# Altium schematic sheet [10] Port Parallèle.SchDoc("[10] Port Parallèle")
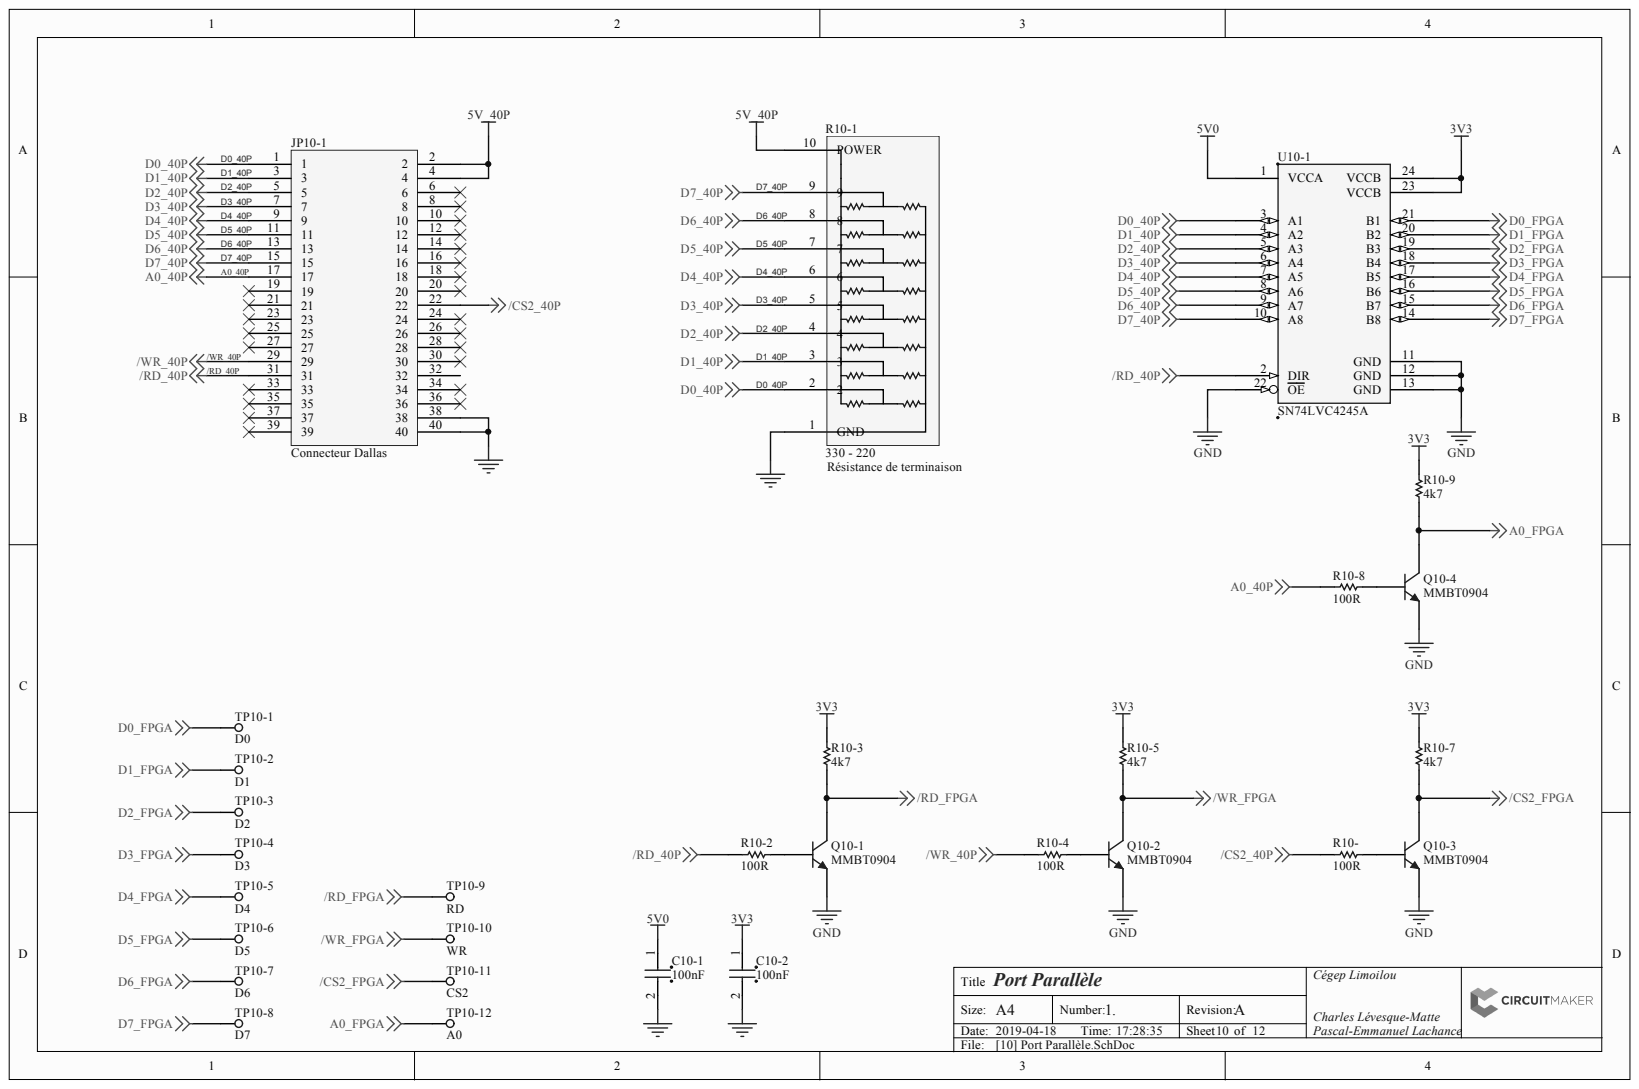
[diagram p0: [10] Port Parallèle.SchDoc]

## Parts
C10-1  100nF  [PCB-mhhqftc5ilnrg4qi8ds6-1]
C10-2  100nF  [PCB-mhhqftc5ilnrg4qi8ds6-1]
JP10-1  Connecteur Dallas  [PCB-2tivjpm26d3sbs9ypqzf-1]
Q10-1  MMBT0904  [PCB-srjv7gtagyvmjlovjbdg-1]
Q10-2  MMBT0904  [PCB-srjv7gtagyvmjlovjbdg-1]
Q10-3  MMBT0904  [PCB-srjv7gtagyvmjlovjbdg-1]
Q10-4  MMBT0904  [PCB-srjv7gtagyvmjlovjbdg-1]
R10-  100R  [PCB-jwfwj9ae82aydnc3cobw-1]
R10-1  330 - 220  [PCBComponent_1]
R10-2  100R  [PCB-jwfwj9ae82aydnc3cobw-1]
R10-3  4k7  [PCB-g8ucq7vw1s2sdhfr4zem-1]
R10-4  100R  [PCB-jwfwj9ae82aydnc3cobw-1]
R10-5  4k7  [PCB-g8ucq7vw1s2sdhfr4zem-1]
R10-7  4k7  [PCB-g8ucq7vw1s2sdhfr4zem-1]
R10-8  100R  [PCB-jwfwj9ae82aydnc3cobw-1]
R10-9  4k7  [PCB-g8ucq7vw1s2sdhfr4zem-1]
TP10-1  D0  [PCB-a7rpxwhzqdkz91v608la-1]
TP10-2  D1  [PCB-a7rpxwhzqdkz91v608la-1]
TP10-3  D2  [PCB-a7rpxwhzqdkz91v608la-1]
TP10-4  D3  [PCB-a7rpxwhzqdkz91v608la-1]
TP10-5  D4  [PCB-a7rpxwhzqdkz91v608la-1]
TP10-6  D5  [PCB-a7rpxwhzqdkz91v608la-1]
TP10-7  D6  [PCB-a7rpxwhzqdkz91v608la-1]
TP10-8  D7  [PCB-a7rpxwhzqdkz91v608la-1]
TP10-9  RD  [PCB-a7rpxwhzqdkz91v608la-1]
TP10-10  WR  [PCB-a7rpxwhzqdkz91v608la-1]
TP10-11  CS2  [PCB-a7rpxwhzqdkz91v608la-1]
TP10-12  A0  [PCB-a7rpxwhzqdkz91v608la-1]
U10-1  SN74LVC4245A  [PCB-19361416-1]

## Nets
3V3: C10-2.1 R10-3.2 R10-5.2 R10-7.2 R10-9.2 U10-1.23(VCCB) U10-1.24(VCCB)
5V0: C10-1.1 U10-1.1(VCCA)
5V_40P: JP10-1.2 JP10-1.4 R10-1.10(POWER)
/CS2_40P: JP10-1.22 R10-.1
/CS2_FPGA: Q10-3.3 R10-7.1 TP10-11.1
/RD_FPGA: Q10-1.3 R10-3.1 TP10-9.1
/WR_FPGA: Q10-2.3 R10-5.1 TP10-10.1
A0_FPGA: Q10-4.3 R10-9.1 TP10-12.1
D0_FPGA: TP10-1.1 U10-1.21(B1)
D1_FPGA: TP10-2.1 U10-1.20(B2)
D2_FPGA: TP10-3.1 U10-1.19(B3)
D3_FPGA: TP10-4.1 U10-1.18(B4)
D4_FPGA: TP10-5.1 U10-1.17(B5)
D5_FPGA: TP10-6.1 U10-1.16(B6)
D6_FPGA: TP10-7.1 U10-1.15(B7)
D7_FPGA: TP10-8.1 U10-1.14(B8)
GND: C10-1.2 C10-2.2 JP10-1.38 JP10-1.40 Q10-1.2 Q10-2.2 Q10-3.2 Q10-4.2 R10-1.1(GND) U10-1.11(GND) U10-1.12(GND) U10-1.13(GND) U10-1.22(OE)
/RD_40P: JP10-1.31 R10-2.1 U10-1.2(DIR)
/WR_40P: JP10-1.29 R10-4.1
A0_40P: JP10-1.17 R10-8.1
D0_40P: JP10-1.1 R10-1.2 U10-1.3(A1)
D1_40P: JP10-1.3 R10-1.3 U10-1.4(A2)
D2_40P: JP10-1.5 R10-1.4 U10-1.5(A3)
D3_40P: JP10-1.7 R10-1.5 U10-1.6(A4)
D4_40P: JP10-1.9 R10-1.6 U10-1.7(A5)
D5_40P: JP10-1.11 R10-1.7 U10-1.8(A6)
D6_40P: JP10-1.13 R10-1.8 U10-1.9(A7)
D7_40P: JP10-1.15 R10-1.9 U10-1.10(A8)
NetQ10-1_1: Q10-1.1 R10-2.2
NetQ10-2_1: Q10-2.1 R10-4.2
NetQ10-3_1: Q10-3.1 R10-.2
NetQ10-4_1: Q10-4.1 R10-8.2

Not connected: JP10-1 6 8 10 12 14 16 18 19 20 21 23 24 25 26 27 28 30 32 33 34 35 36 37 39

## Library data of the parts
C10-1 C10-2 = CMP-1af8d38ac64bc53f-4: Manufacturer: Samsung Electro-Mechanics; Manufacturer Part Number: CL10B104KB8NNNC; Vendor Part Number: 1276-1000-1-ND; Capacitance: 0.1µF; Temperature Coefficient: X7R; Tolerance: ±10%; Vendor: Digikey; Voltage - Rated: 50V; Applications: General Purpose; Component Kind: Standard; HelpURL: https://media.digikey.com/pdf/Data%20Sheets/Samsung%20PDFs/CL_Series_MLCC_ds.pdf; Library Name: CommunityVault; Mounting Type: Surface Mount, MLCC; OctopartID: 1af8d38ac64bc53f; Octopart URL: https://octopart.com/cl10b104kb8nnnc-samsung-7928277; Operating Temperature: -55°C ~ 125°C; Package / Case: 0603 (1608 Metric); Size / Dimension: 0.063" L x 0.031" W (1.60mm x 0.80mm); Thickness (Max): 0.035" (0.90mm)
JP10-1 = CMP-2555ec56f870fa92-1: Conn Shrouded Header (4 Sides) HDR 40 POS 2.54mm Solder RA Thru-Hole Box; Manufacturer Part Number: 30340-5002HB; Gender: Male; Lead-Free Status: Lead Free; Component Kind: Standard; Library Name: CommunityVault; OctopartID: 2555ec56f870fa92; RoHS: Compliant
Q10-1-Q10-4 = CMP-22e1eb52d348fd26-2: Transistor: 200mA 200mW NPN General-Purpose Amplifier, SOT-23, 7IN. Reel; Current Rating: 100 mA; Lead-Free Status: Lead Free; Power Dissipation: 350 mW (max); Component Kind: Standard; Library Name: CommunityVault; OctopartID: 22e1eb52d348fd26
R10- R10-2 R10-4 R10-8 = CMP-8a5c42738545559b-1: RMCF Series 0603 0.1 W 100 Ohm ±1 % ±100 ppm/°C SMT Thick Film Chip Resistor; Manufacturer Part Number: RMCF0603FT100R; Lead-Free Status: Lead Free; Power Rating: 100 mW; Resistance: 100 Ω; Resistance Tolerance: ±1%; Case/Package: 0603; Component Kind: Standard; Library Name: CommunityVault; Mounting Style: Surface Mount; OctopartID: 8a5c42738545559b; Octopart URL: http://octopart.com/rmcf0603ft100r-stackpole+electronics-19212927; Packaging: Tape & Reel (TR); RoHS: Compliant
R10-1 = CMP-9d6c5474ac4f42ab-1: Res Thick Film NET 220 Ohm/330 Ohm 2% 1.25W ±100ppm/°C TERM Conformal 10-Pin SIP Pin Thru-Hole Bulk; Manufacturer Part Number: 4610X-104-221/331L; Insulation Resistance: 10.0 M?; Lead-Free Status: Lead Free; Lead Length: 3.18 mm; Number of Contacts: 10; Resistance: 330 ?; Temperature Coefficient: -100 ppm/°C to 100 ppm/°C; Voltage Rating (DC): 100 V; Case/Package: SIP; Component Kind: Standard; Library Name: FavoritesLibrary; Mounting Style: Through Hole; Number of Pins: 10; OctopartID: 9d6c5474ac4f42ab; Octopart URL: https://octopart.com/4610x-104-221%2F331l-bourns-1184837; Operating Temperature: -55.0 °C to 125 °C; Packaging: Bulk; Power Rating: 1.25 W; REACH SVHC Compliance: No SVHC; Resistance Tolerance: ±2%; RoHS: Compliant; Size-Height: 5.08 mm; Size-Length: 25.4 mm; Size-Thickness: 2.49 mm; Size-Width: 2.49 mm
R10-3 R10-5 R10-7 R10-9 = CMP-011ec9310fadded9-4: Res Thick Film 0603 4.7K Ohm 1% 0.1W(1/10W) ±100ppm/C Pad SMD Automotive T/R; Resistance: 4.70 kΩ; Case/Package: 0603; Component Kind: Standard; Library Name: CommunityVault; Mounting Style: Surface Mount
TP10-1-TP10-12 = CMP-5j384tb079h9dvgbp04a-2: Through-hole Testpoint; Component Kind: Standard; Library Name: CommunityVault
U10-1 = CMP-19361416-3: Level shifter; Manufacturer Part Number: SN74LVC4245ADWR; Lead-Free Status: Lead Free; Number of Bits: 8; Output Current: 0.024 A; Output Current Drive: -0.001 A; Propagation Delay Max (tpd): 3.7e-09 s; Supply Voltage (DC): 3.0 V; Case/Package: SOIC; Component Kind: Standard; Library Name: CommunityVault; Lifecycle Status: Active; Mounting Style: Surface Mount; Number of Circuits: 8; Number of Outputs: 8; Number of Pins: 24; OctopartID: 56bb9fb690a7897c; Octopart Url: https://octopart.com/sn74lvc4245adwr-texas+instruments-516986; REACH SVHC Compliance: No SVHC; RoHS: Compliant
